# Altium schematic sheet BSPD_SHEET_3.SchDoc(BSPD_SHEET_3)
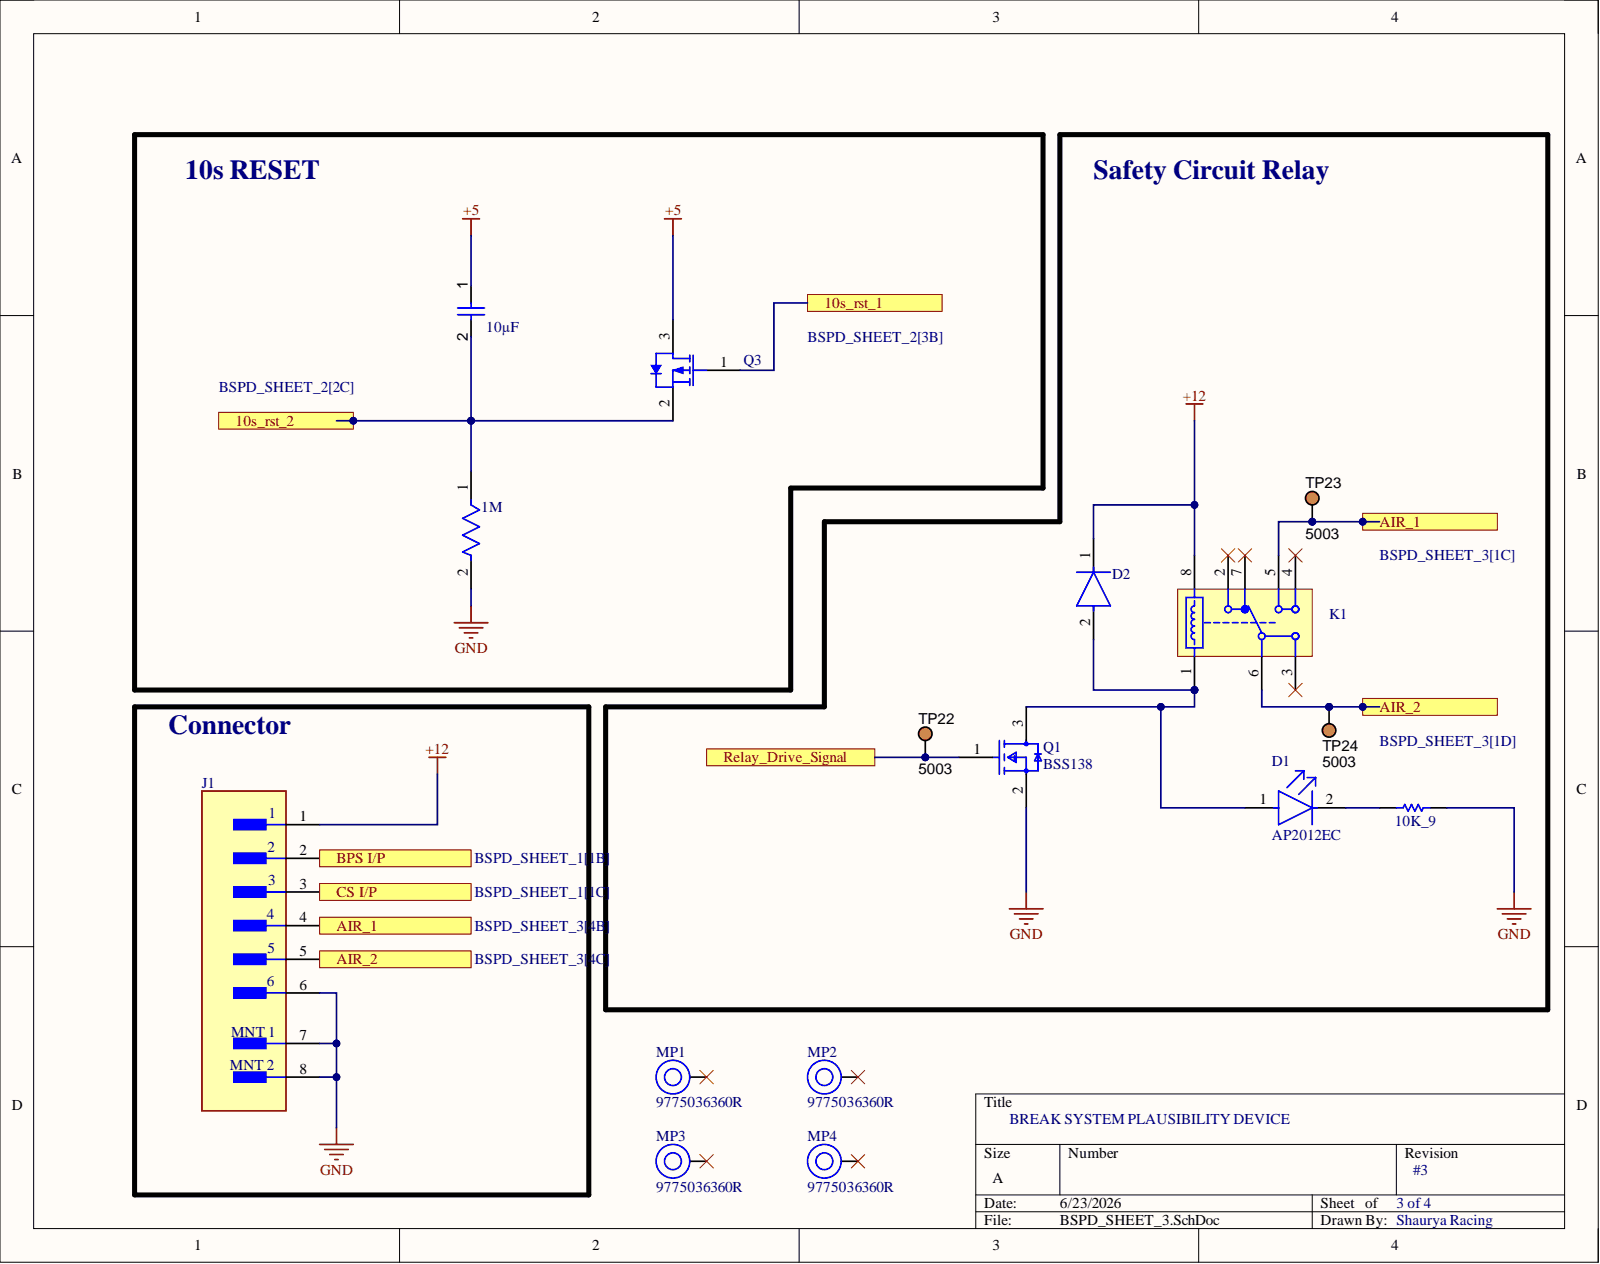
[diagram p0: BSPD_SHEET_3.SchDoc]

## Parts
1M  MCU08050D1004BP500  (value not drawn)
10K_9  TNPW040210K0DEED  (value not drawn)
C1  value 10µF  (hidden comment: Capacitor 10µF +/-40% 6.3V 0402)
D1  AP2012EC
D2  1N4148  (value not drawn)
J1  BM06B-ZESS-TBT(LF)(SN)  (value not drawn)
K1  ALZ11B12  (value not drawn)
MP1  9775036360R
MP2  9775036360R
MP3  9775036360R
MP4  9775036360R
Q1  BSS138
Q3  SI2319CDS-T1-GE3  (value not drawn)
TP22  5003
TP23  5003
TP24  5003

## Ports
10s_rst_1, 10s_rst_2, AIR_1, AIR_2, BPS I/P, CS I/P, Relay_Drive_Signal

## Nets
+5: C1.1 Q3.3
+12: D2.1 J1.1 K1.8
GND: 1M.2 10K_9.2 J1.6 J1.7 J1.8 Q1.2
10s_rst_1: Q3.1 ; port 10s_rst_1
10s_rst_2: 1M.1 C1.2 Q3.2 ; port 10s_rst_2
AIR_1: J1.4 K1.5 TP23.1 ; port AIR_1
AIR_2: J1.5 K1.6 TP24.1 ; port AIR_2
BPS I/P: J1.2 ; port BPS I/P
CS I/P: J1.3 ; port CS I/P
Relay_Drive_Signal: Q1.1 TP22.1 ; port Relay_Drive_Signal
Net10K_9_1: 10K_9.1 D1.2
NetD1_1: D1.1 D2.2 K1.1 Q1.3

Not connected: K1 2 3 4 7; MP1 1; MP2 1; MP3 1; MP4 1

## Library data of the parts
1M = CMP-02407-001361-1: RES 1.05K OHM 0.5% 1/5W 0805; Resistance: 1MR; Tolerance: 0.1%; Case/Package: 0805; Component Kind: Standard; Height: 450um; Lead Free: Lead Free; Length: 2mm; Library Name: Altium Content Vault; Width: 1.25mm
10K_9 = CMP-2002-01682-1: Manufacturer: Vishay BCcomponents; Component Kind: Standard; Library Name: Altium Content Vault; Part Number: TNPW040210K0DEED
C1 = CMP-001-00074-3: Chip Capacitor, 10µF +/-40%, 6.3V, 0402, Thickness 0.6 mm; Tolerance: 10%; Case/Package: 0402; Component Kind: Standard; Max Operating Temperature: 85°C; Min Operating Temperature: -55°C; Mounting Technology: SMT; Pins: 2; Voltage Rating: 6.3V; Library Name: APAC - VIT, Chennai - Shaurya Racing
D1 = CMP-44529-000070-1: LED RED CLEAR SMD; Dominant Wavelength: 625nm; Forward Current: 20mA; Forward Voltage: 2V; Luminous Intensity: 15mcd; Viewing Angle: 120°; Wavelength: 627nm; Case Code (Imperial): 0805; Case Code (Metric): 2012; Case/Package: 0805; Color: Red; Component Kind: Standard; Height: 1.1mm; Illumination Color: Red; Lead Free: Lead Free; Length: 2mm; Lens Style: Clear; Library Name: Altium Content Vault; Max Operating Temperature: 85°C; Min Operating Temperature: -40°C; Mount: Surface Mount; Package Quantity: 2000; Packaging: Tape and Reel; RoHS Compliant: Yes; Test Current: 20mA; Width: 1.25mm
D2 = CMP-07163-000046-1: DIODE GEN PURP 100V 200MA DO35; Capacitance: 4pF; Current: 4A; Element Configuration: Single; Forward Current: 300mA; Forward Voltage: 1V; Max Forward Surge Current (Ifsm): 1A; Max Power Dissipation: 500mW; Max Reverse Current: 5uA; Outside Diameter: 1.85mm; Polarity: Standard; Recovery Time: 4ns; Average Rectified Current: 200mA; Case/Package: DO-35; Component Kind: Standard; Contact Plating: Tin; Current Rating: 200mA; Diameter: 1.91mm; Height: 4.56mm; Lead Free: Lead Free; Length: 4.56mm; Library Name: Altium Content Vault; Max Operating Temperature: 175°C; Max Repetitive Reverse Voltage (Vrrm): 100V; Max Reverse Voltage (DC): 100V; Max Surge Current: 4A; Min Operating Temperature: -65°C; Mount: Through Hole; Number of Pins: 2; Output Current: 200mA; Package Quantity: 2000; Packaging: Bulk; Peak Non-Repetitive Surge Current: 4A; Power Dissipation: 500mW; Radiation Hardening: No; REACH SVHC: No SVHC; Reverse Recovery Time: 4ns; Reverse Voltage: 100V; Series: -; Voltage: 100V; Voltage Rating (DC): 100V; Weight: 0.01lb; Width: 1.91mm
J1 = CMP-17439-000569-1: CONN HEADER SMD 6POS 1.5MM; Current Rating: 2A; Mated Stacking Heights: 9.4mm; Number of Contacts: 6; Voltage Rating: 100V; Color: Natural; Component Kind: Standard; Connector Type: Header; Contact Finish - Mating: TIN; Contact Material: Copper Alloy; Contact Plating: Tin; Contact Shape: Rectangular; Contact Type: Outer Shroud Contact; Fastening Type: Ramp; Flammability Rating: UL94 V-0; Insulation Color: Natural; Insulation Material: Nylon; Library Name: Altium Content Vault; Max Operating Temperature: 85°C; Min Operating Temperature: -25°C; Mount: Surface Mount; Number of Positions: 6; Number of Rows: 1; Orientation: Straight; Packaging: Cut Tape; Pitch: 1.5mm; Series: ZE; Termination: Solder
K1 = CMP-1503-00243-1: LZ Relays, 12 V Coil Voltage, 400 mW Nominal Operating Power, -40 to 85 degC, 8-Pin LZ, Carton, Case, RoHS; Manufacturer: Panasonic; Coil Voltage: 12 V; Electrical Life: 1x10^5 (N.O.), 5x10^4 (N.C.); Max Switching Current: 16 A; Max Switching Voltage: 440 V AC; Mechanical Life(Min.operation): 1x10^7; Nominal Operating Power: 400 mW; Pb-Free: True; Component Kind: Standard; ComponentLink1Description: Manufacturer URL; ComponentLink1URL: http://www3.panasonic.biz/ac/ae/index.jsp; ComponentLink2Description: Datasheet; ComponentLink2URL: http://www3.panasonic.biz/ac/e_download/control/relay/power/catalog/mech_eng_lz.pdf?f_cd=300372; ComponentLink3Description: Package Specification; ComponentLink3URL: http://www3.panasonic.biz/ac/e_download/control/relay/power/catalog/mech_eng_lz.pdf?f_cd=300372; DatasheetVersion: 201402-T; Library Name: Altium Content Vault; Mounting Technology: Through Hole; PackageDescription: 8-Pin Through Hole Terminal Package, Body 28.8 x 12.5 mm; PackageReference: LZ-8-2880x1250x1570; PackageVersion: ASCTB203E, 02/2014; Packing: Carton, Case; PartNumber: ALZ11B12; RoHS: True; Series: LZ Relays
MP1-MP4 = CMP-03913-78872569-2: WA-SMSR SMT Steel Spacer, Reverse M3 non Stop; Pin Length: 3.6mm; Component Kind: Standard; Length: 3.6mm; Library Name: Altium Content Vault; Material: Steel; Max Operating Temperature: 150°C; Min Operating Temperature: -55°C; Packaging: Tape and Reel; REACH SVHC: No; RoHS: Compliant
Q1 = CMP-07173-000101-1: MOSFET N-CH 50V 220MA SOT-23; Drain to Source Resistance: 3.5R; Element Configuration: Single; Fall Time: 9ns; Gate to Source Voltage (Vgs): 20V; Input Capacitance: 27pF; Max Power Dissipation: 360mW; Nominal Vgs: 1.3V; Number of Elements: 1; On-State Resistance: 6R; Turn-Off Delay Time: 20ns; Turn-On Delay Time: 2.5ns; Case/Package: SOT-23; Component Kind: Standard; Contact Plating: Tin; Continuous Drain Current (ID): 220mA; Current Rating: 220mA; Drain to Source Breakdown Voltage: 50V; Drain to Source Voltage (Vdss): 50V; Dual Supply Voltage: 50V; Height: 0.93mm; Lead Free: Lead Free; Length: 2.92mm; Library Name: Altium Content Vault; Max Operating Temperature: 150°C; Min Operating Temperature: -55°C; Mount: Surface Mount; Number of Pins: 3; Package Quantity: 1; Packaging: Tape and Reel; Power Dissipation: 360mW; Radiation Hardening: No; Rds On Max: 3.5R; REACH SVHC: No SVHC; Rise Time: 9ns; Termination: SMD/SMT; Threshold Voltage: 1.3V; Voltage Rating (DC): 50V; Weight: 0.03g; Width: 1.3mm
Q3 = CMP-2000-07053-1: P-Channel (D-S) MOSFET, 40 V, -55 to 150 degC, 3-Pin SOT23 (TO-236), RoHS, Tape and Reel; Manufacturer: Vishay; Brand: VishaySemiconductors; Configuration: Single; Forward Transconductance - Min: 10S; Id - Continuous Drain Current: -4.4A; Number of Channels: 1Channel; Package Version: Rev. K, 07/2001; Pd - Power Dissipation: 2.5W; Qg - Gate Charge: 13.6nC; Rds On - Drain-Source Resistance: 64mOhms; Transistor Type: 1P-Channel; Unit Weight: 0.050717oz; Vds - Drain-Source Breakdown Voltage: -40V; Component Kind: Standard; Datasheet URL: http://www.vishay.com/docs/66709/si2319cd.pdf; Datasheet Version: Rev. A, 05/2010; Factory Pack Quantity: 3000; Library Name: Altium Content Vault; Manufacturer URL: http://www.vishay.com/; Maximum Operating Temperature: +150C; Minimum Operating Temperature: -55C; Mounting Style: SMD/SMT; Mounting Technology: SurfaceMount; Package / Case: SOT-23-3; Package Description: 3-Pin Small Outline Transistor, Body 2.92 x 1.3 mm, Pitch 0.95 mm; Package Reference: TO-236-3; Package URL: http://www.vishay.com/docs/71196/71196.pdf; Packaging: Reel; Product: MOSFETSmallSignal; Product Category: MOSFET; RoHS: True; Series: SI2; Technology: Si; Tradename: TrenchFET; Transistor Polarity: P-Channel
TP22-TP24 = CMP-002-00037-2: Test Point, Orange, Height 4.6 mm, Tail Length 3 mm, 1-Pin THD, RoHS; Manufacturer: Keystone; Case/Package: 5003; Colour: Orange; Component Kind: Standard; Connector Type: TestPoint; Contact Material: Bronze; Contact Plating: Tin; Datasheet URL: http://keyelco.com/userAssets/file/M65p56.pdf; Datasheet Version: Jun-15; Diameter: 2.54mm; Manufacturer URL: http://www.keyelco.com/; Mounting Technology: TH; Package Description: 1-Pin Through Hole Device, Dia 2.54 mm; Package Quantity: 10; Package Reference: 5003; Packaging: Bulk; Pins: 1; RoHS Compliant: True; Library Name: APAC - VIT, Chennai - Shaurya Racing
